# Altium schematic sheet Sheet3.SchDoc
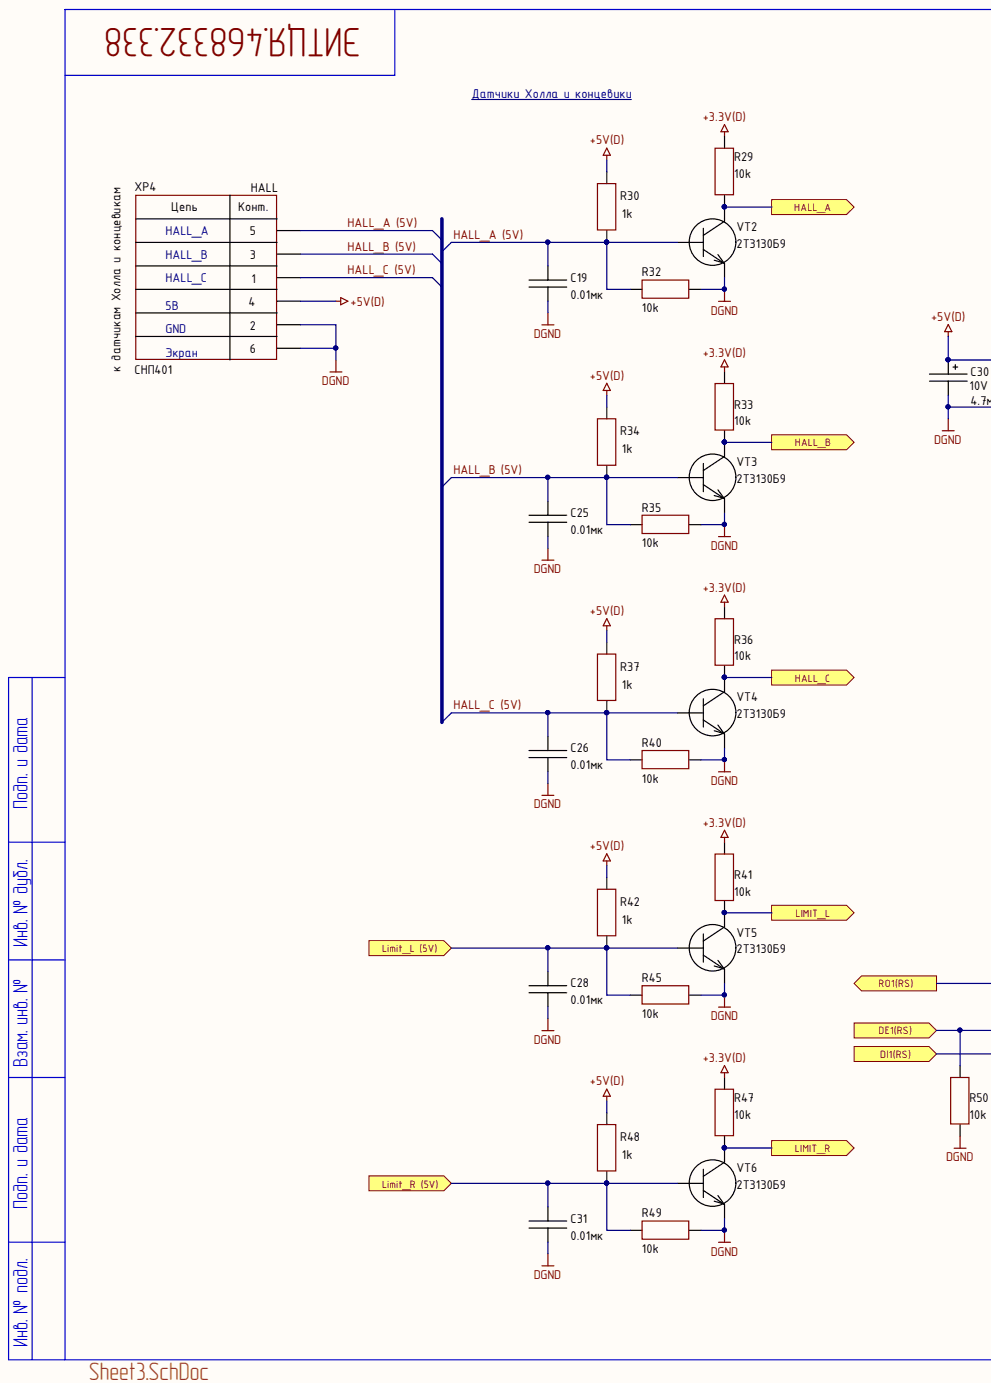
[diagram p0: Sheet3.SchDoc, left]
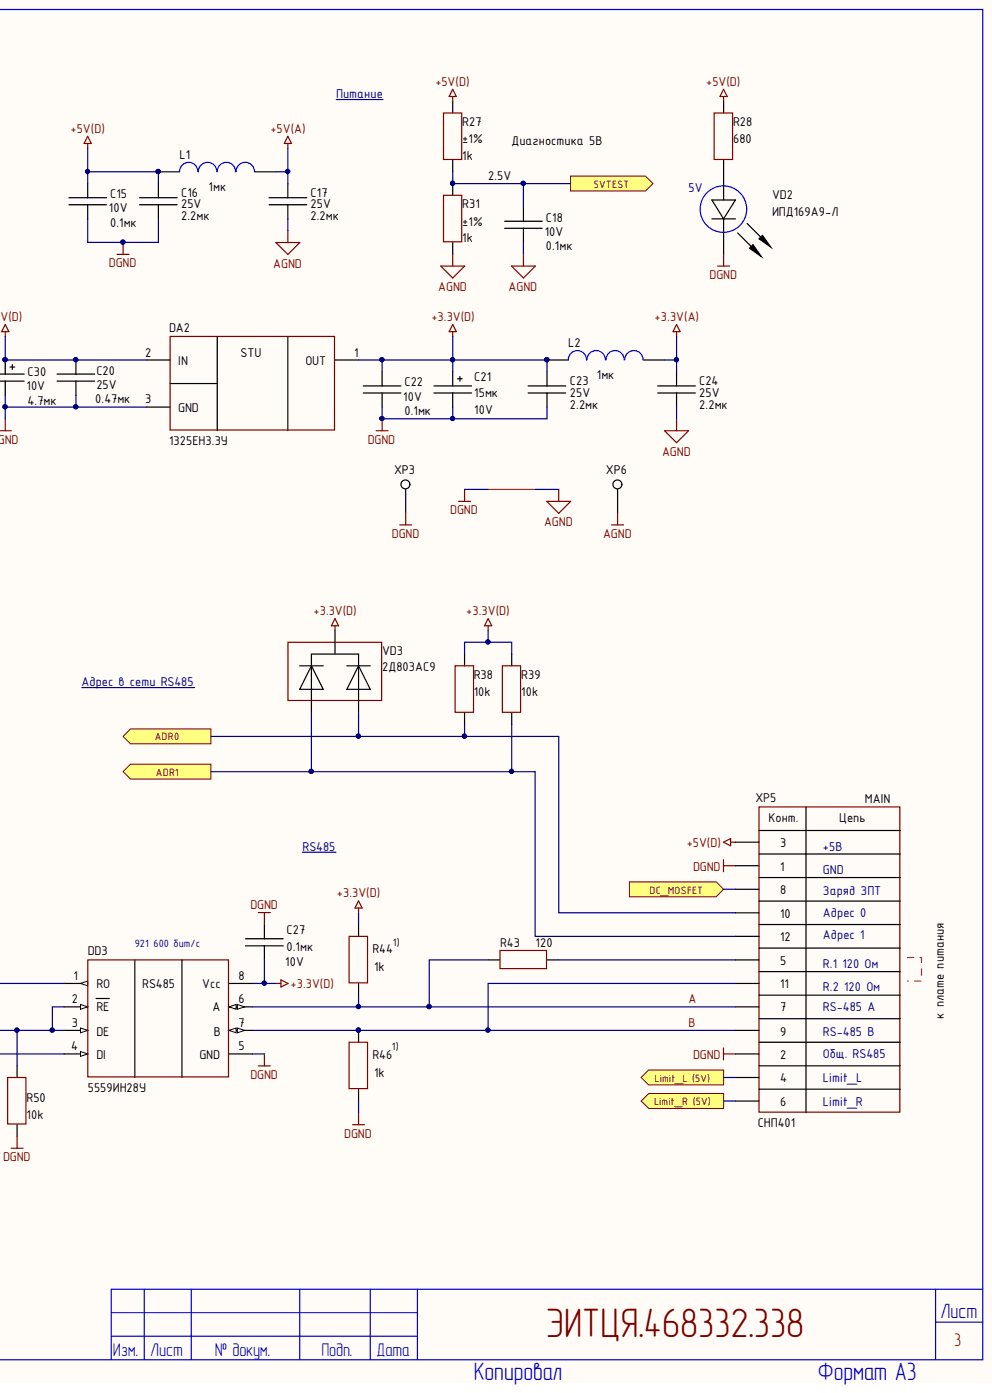
[diagram p1: Sheet3.SchDoc, right]

## Parts
C15  value 0.1мк  Voltage: 10V  [CAP: 0603P]  (hidden comment: Ceramic capacitor, 0.1мк, H90, +80-20%, 10V, 0603, -55...+125°C)
C16  value 2.2мк  Voltage: 25V  [CAP: 1210]  (hidden comment: Ceramic capacitor, 4.7мк, H90, +80 -20%, 25V, 1812 (4532М) (4.5x3.2), -55...+125°C)
C17  value 2.2мк  Voltage: 25V  [CAP: 1210]  (hidden comment: Ceramic capacitor, 4.7мк, H90, +80 -20%, 25V, 1812 (4532М) (4.5x3.2), -55...+125°C)
C18  value 0.1мк  Voltage: 10V  [CAP: 0603P]  (hidden comment: Ceramic capacitor, 0.1мк, H90, +80-20%, 10V, 0603, -55...+125°C)
C19  value 0.01мк  [CAP: 0603P]  (hidden comment: Ceramic capacitor, 0.01мк, H20, ±20%, 50V, 0603, -55...+125°C)
C20  value 0.47мк  Voltage: 25V  [CAP: 1210]  (hidden comment: Ceramic capacitor, 0.47мк, Н20, ±10%, 25V, 1210 (3225M),)
C21  value 15мк  Voltage: 10V  [CAP: TANTAL-B]  (hidden comment: Tantalum capacitor, 15мк, 10V, ±10%, , case B (3528), -55...+125°C)
C22  value 0.1мк  Voltage: 10V  [CAP: 0603P]  (hidden comment: Ceramic capacitor, 0.1мк, H90, +80-20%, 10V, 0603, -55...+125°C)
C23  value 2.2мк  Voltage: 25V  [CAP: 1210]  (hidden comment: Ceramic capacitor, 4.7мк, H90, +80 -20%, 25V, 1812 (4532М) (4.5x3.2), -55...+125°C)
C24  value 2.2мк  Voltage: 25V  [CAP: 1210]  (hidden comment: Ceramic capacitor, 4.7мк, H90, +80 -20%, 25V, 1812 (4532М) (4.5x3.2), -55...+125°C)
C25  value 0.01мк  [CAP: 0603P]  (hidden comment: Ceramic capacitor, 0.01мк, H20, ±20%, 50V, 0603, -55...+125°C)
C26  value 0.01мк  [CAP: 0603P]  (hidden comment: Ceramic capacitor, 0.01мк, H20, ±20%, 50V, 0603, -55...+125°C)
C27  value 0.1мк  Voltage: 10V  [CAP: 0603P]  (hidden comment: Ceramic capacitor, 0.1мк, H90, +80-20%, 10V, 0603, -55...+125°C)
C28  value 0.01мк  [CAP: 0603P]  (hidden comment: Ceramic capacitor, 0.01мк, H20, ±20%, 50V, 0603, -55...+125°C)
C30  value 4.7мк  Voltage: 10V  [CAP: TANTAL-A]  (hidden comment: Tantalum capacitor, 4.7мк, 10V, ±10%, 2Ohm, case A (3216), -55...+125°C)
C31  value 0.01мк  [CAP: 0603P]  (hidden comment: Ceramic capacitor, 0.01мк, H20, ±20%, 50V, 0603, -55...+125°C)
DA2  PartNumber: 1325ЕН3.3У  [KT-93-1]  (hidden comment: Linear voltage regulator, input 4.75...15V, 1.3V, output 3.3V, 0.8A, -40...+85°C, КТ-93-1)
DD3  5559ИН28У  [Н02.8-1В]
L1  value 1мк  [IND: 0603]  (hidden comment: Fixed inductor, 1мк, ±20%, 0603, 400mA, -55...+125°C)
L2  value 1мк  [IND: 0603]  (hidden comment: Fixed inductor, 1мк, ±20%, 0603, 400mA, -55...+125°C)
NT1  ValueManufacturerPartNumber  [NetTie_0603]  (value not drawn)
R27  value 1k  Tolerance: ±1%  [RES: 0603P]  (hidden comment: Resistor film, 1k, ±1%, 0603, 0.1W, -55...+125°C)
R28  value 680  [RES: 0603P]  (hidden comment: Resistor film, 680, ±5%, 0603, 0.1W, -55...+125°C)
R29  value 10k  [RES: 0603P]  (hidden comment: Resistor film, 10k, ±5%, 0603, 0.1W, -55...+125°C)
R30  value 1k  [RES: 0603P]  (hidden comment: Resistor film, 1k, ±1%, 0603, 0.1W, -55...+125°C)
R31  value 1k  Tolerance: ±1%  [RES: 0603P]  (hidden comment: Resistor film, 1k, ±1%, 0603, 0.1W, -55...+125°C)
R32  value 10k  [RES: 0603P]  (hidden comment: Resistor film, 10k, ±5%, 0603, 0.1W, -55...+125°C)
R33  value 10k  [RES: 0603P]  (hidden comment: Resistor film, 10k, ±5%, 0603, 0.1W, -55...+125°C)
R34  value 1k  [RES: 0603P]  (hidden comment: Resistor film, 1k, ±1%, 0603, 0.1W, -55...+125°C)
R35  value 10k  [RES: 0603P]  (hidden comment: Resistor film, 10k, ±5%, 0603, 0.1W, -55...+125°C)
R36  value 10k  [RES: 0603P]  (hidden comment: Resistor film, 10k, ±5%, 0603, 0.1W, -55...+125°C)
R37  value 1k  [RES: 0603P]  (hidden comment: Resistor film, 1k, ±1%, 0603, 0.1W, -55...+125°C)
R38  value 10k  [RES: 0603P]  (hidden comment: Resistor film, 10k, ±5%, 0603, 0.1W, -55...+125°C)
R39  value 10k  [RES: 0603P]  (hidden comment: Resistor film, 10k, ±5%, 0603, 0.1W, -55...+125°C)
R40  value 10k  [RES: 0603P]  (hidden comment: Resistor film, 10k, ±5%, 0603, 0.1W, -55...+125°C)
R41  value 10k  [RES: 0603P]  (hidden comment: Resistor film, 10k, ±5%, 0603, 0.1W, -55...+125°C)
R42  value 1k  [RES: 0603P]  (hidden comment: Resistor film, 1k, ±1%, 0603, 0.1W, -55...+125°C)
R43  value 120  [RES: 0805P]  (hidden comment: Resistor film, 120, ±5%, 0805, 0.125W, -55...+125°C)
R44  value 1k  [RES: 0603P]  (hidden comment: Resistor film, 1k, ±1%, 0603, 0.1W, -55...+125°C)
R45  value 10k  [RES: 0603P]  (hidden comment: Resistor film, 10k, ±5%, 0603, 0.1W, -55...+125°C)
R46  value 1k  [RES: 0603P]  (hidden comment: Resistor film, 1k, ±1%, 0603, 0.1W, -55...+125°C)
R47  value 10k  [RES: 0603P]  (hidden comment: Resistor film, 10k, ±5%, 0603, 0.1W, -55...+125°C)
R48  value 1k  [RES: 0603P]  (hidden comment: Resistor film, 1k, ±1%, 0603, 0.1W, -55...+125°C)
R49  value 10k  [RES: 0603P]  (hidden comment: Resistor film, 10k, ±5%, 0603, 0.1W, -55...+125°C)
R50  value 10k  [RES: 0603P]  (hidden comment: Resistor film, 10k, ±5%, 0603, 0.1W, -55...+125°C)
VD2  CommentText: 5V  PartNumber: ИПД169А9-Л  [LED: 0603G]  (hidden comment: LED, 20mA max, 2.1V typ, 1608, -55...+150°C)
VD3  PartNumber: 2Д803АС9  [SOT23]  (hidden comment: Diode array common anode, 50V, 200mA, 1.1V, , SOT-23,)
VT2  PartNumber: 2Т3130Б9  [SOT23]  (hidden comment: NPN transistor, 30V, 100mA, , 300mW, 0.7V, 500, SOT-23 (КТ-46), -55...+125°C)
VT3  PartNumber: 2Т3130Б9  [SOT23]  (hidden comment: NPN transistor, 30V, 100mA, , 300mW, 0.7V, 500, SOT-23 (КТ-46), -55...+125°C)
VT4  PartNumber: 2Т3130Б9  [SOT23]  (hidden comment: NPN transistor, 30V, 100mA, , 300mW, 0.7V, 500, SOT-23 (КТ-46), -55...+125°C)
VT5  PartNumber: 2Т3130Б9  [SOT23]  (hidden comment: NPN transistor, 30V, 100mA, , 300mW, 0.7V, 500, SOT-23 (КТ-46), -55...+125°C)
VT6  PartNumber: 2Т3130Б9  [SOT23]  (hidden comment: NPN transistor, 30V, 100mA, , 300mW, 0.7V, 500, SOT-23 (КТ-46), -55...+125°C)
XP3  DGND  [CON: PLS2-1]
XP4  CommentText: СНП401  [CON: M80-5000605]  (hidden comment: Вилка, 6pin, 2mm, 120VAC, 1.5A)
XP5  CommentText: СНП401  [CON: M80-5001205]  (hidden comment: Вилка, 12pin, 2mm, 100V, 1.5A)
XP6  AGND  [CON: PLS2-1]

## Ports
5VTEST, ADR0, ADR1, DC_MOSFET, DE1(RS), DI1(RS), HALL_A, HALL_B, HALL_C, LIMIT_L, Limit_L (5V), LIMIT_R, Limit_R (5V), RO1(RS)

## Nets
+3.3V(A): C24.2 L2.1
+3.3V(D): C21.1 C22.2 C23.2 C27.1 DA2.1 DD3.8(Vcc) L2.2 R29.1 R33.1 R36.1 R38.1 R39.1 R41.1 R44.1 R47.1 VD3.3
+5V(A): C17.2 L1.1
+5V(D): C15.2 C16.2 C20.2 C30.1 DA2.2 L1.2 R27.1 R28.1 R30.1 R34.1 R37.1 R42.1 R48.1 XP4.4 XP5.3
AGND: C17.1 C18.1 C24.1 NT1.2 R31.2 XP6.1
DGND: C15.1 C16.1 C19.2 C20.1 C21.2 C22.1 C23.1 C25.2 C26.2 C27.2 C28.2 C30.2 C31.2 DA2.3 DD3.5(GND) DD3.9 NT1.1 R32.1 R35.1 R40.1 R45.1 R46.2 R49.1 R50.2 VD2.2 VT2.2 VT3.2 VT4.2 VT5.2 VT6.2 XP3.1 XP4.2 XP4.6 XP5.1 XP5.2
5VTEST: C18.2 R27.2 R31.1 ; port 5VTEST
A: DD3.6(A) R43.2 R44.2 XP5.7
ADR0: R38.2 VD3.2 XP5.10 ; port ADR0
ADR1: R39.2 VD3.1 XP5.12 ; port ADR1
B: DD3.7(B) R46.1 XP5.9 XP5.11
DC_MOSFET: XP5.8 ; port DC_MOSFET
DE1(RS): DD3.2(RE) DD3.3(DE) R50.1 ; port DE1(RS)
DI1(RS): DD3.4(DI) ; port DI1(RS)
HALL_A: R29.2 VT2.3 ; port HALL_A
HALL_A (5V): C19.1 R30.2 R32.2 VT2.1 XP4.5
HALL_B: R33.2 VT3.3 ; port HALL_B
HALL_B (5V): C25.1 R34.2 R35.2 VT3.1 XP4.3
HALL_C: R36.2 VT4.3 ; port HALL_C
HALL_C (5V): C26.1 R37.2 R40.2 VT4.1 XP4.1
LIMIT_L: R41.2 VT5.3 ; port LIMIT_L
Limit_L (5V): C28.1 R42.2 R45.2 VT5.1 XP5.4 ; port Limit_L (5V)
LIMIT_R: R47.2 VT6.3 ; port LIMIT_R
Limit_R (5V): C31.1 R48.2 R49.2 VT6.1 XP5.6 ; port Limit_R (5V)
RO1(RS): DD3.1(RO) ; port RO1(RS)
NetR28_2: R28.2 VD2.1
NetR43_1: R43.1 XP5.5
N00110:

## Library data of the parts
C15 C18 C22 C27 = Ceramic_0603: Manufacturer: Гириконд; PartNumber: К10-79-10В-0,1 мкФ +80-20% H90; Component Kind: Standard; HelpURL: http://www.giricond.ru/files/k10-79_vp_2018_saiyt.pdf; Library Name: Capacitor.SchLib; Package: 0603; Temperature: -55...+125°C; Tolerance: +80-20%; TypeCapacitor: Ceramic capacitor; TypeDielectric: H90; ValueManufacturerPartNumber: Ceramic capacitor, 0.1мк, H90, +80-20%, 10V, 0603, -55...+125°C
C16 C17 C23 C24 = Ceramic_1210: Manufacturer: Монолит; PartNumber: К10-84в-3225М-25В-2,2 мкФ +80/-20%-Н90-N-A; Component Kind: Standard; HelpURL: https://www.digikey.com/product-detail/en/yageo/CC1210KKX7R9BB104/311-4522-1-ND/8025611; Library Name: Capacitor.SchLib; Package: 1210 (3225М); Temperature: -55...+125°C; Tolerance: +80 -20%; TypeCapacitor: Ceramic capacitor; TypeDielectric: H90; ValueManufacturerPartNumber: Ceramic capacitor, 4.7мк, H90, +80 -20%, 25V, 1812 (4532М) (4.5x3.2), -55...+125°C
C19 C25 C26 C28 C31 = Ceramic_0603: Manufacturer: Монолит; PartNumber: К10-84в-1608М-50В-0.01мк ±20%-Н20-N-A; Analog: MAX 00131676 (pin-to-pin); Component Kind: Standard; HelpURL: http://www.monolit.vitebsk.by/Files/K10-84.pdf; Library Name: Capacitor.SchLib; Package: 0603; Temperature: -55...+125°C; Tolerance: ±20%; TypeCapacitor: Ceramic capacitor; TypeDielectric: H20; ValueManufacturerPartNumber: Ceramic capacitor, 0.01мк, H20, ±20%, 50V, 0603, -55...+125°C; Voltage: 50V
C20 = Ceramic_0603: Manufacturer: Монолит; PartNumber: К10-84в-3225М-25В-0,47 мкФ ±10%-Н20-N-A; Analog: MAX 00150226; Component Kind: Standard; HelpURL: http://www.monolit.vitebsk.by/Files/K10-84.pdf; Library Name: Capacitor.SchLib; Package: 1210 (3225M); Tolerance: ±10%; TypeCapacitor: Ceramic capacitor; TypeDielectric: Н20; ValueManufacturerPartNumber: Ceramic capacitor, 0.47мк, Н20, ±10%, 25V, 1210 (3225M),
C21 = Tantal_B: Manufacturer: "Элеконд"; PartNumber: К53-68 В-10 В-15 мкФ ±10%; MAX: 00130628; Component Kind: Standard; Library Name: Capacitor.SchLib; Package: case B (3528); Temperature: -55...+125°C; Tolerance: ±10%; TypeCapacitor: Tantalum capacitor; ValueManufacturerPartNumber: Tantalum capacitor, 15мк, 10V, ±10%, , case B (3528), -55...+125°C
C30 = Tantal_A: Manufacturer: Элеконд; PartNumber: К53-68 "А"-10 В-4.7 мкф; MAX: 00130629; TypeDielectric: X7R; Component Kind: Standard; HelpURL: https://www.digikey.com/product-detail/en/avx-corporation/F930J107KAAAJ6/478-12404-1-ND/9645231; Impedance: 2Ohm; Library Name: Capacitor.SchLib; Package: case A (3216); Temperature: -55...+125°C; Tolerance: ±10%; TypeCapacitor: Tantalum capacitor; ValueManufacturerPartNumber: Tantalum capacitor, 4.7мк, 10V, ±10%, 2Ohm, case A (3216), -55...+125°C
DA2 = 1325ЕР1У: Linear voltage regulator 3V3; Manufacturer: Интеграл; MAX: 00141284; Component Kind: Standard; ComponentType: Linear voltage regulator; CurrentOutput: 0.8A; HelpURL: https://www.integral.by/sites/default/files/pdf/specifikaciya_serii_1325.pdf; Library Name: IC. Power supply.SchLib; MaxDropout: 1.3V; Package: КТ-93-1; Temperature: -40...+85°C; ValueManufacturerPartNumber: Linear voltage regulator, input 4.75...15V, 1.3V, output 3.3V, 0.8A, -40...+85°C, КТ-93-1; VoltageInput: input 4.75...15V; VoltageOutput: output 3.3V
DD3 = 5559ИН28У: RS485 USSR; Manufacturer: Миландр; DataRate: 30 Mbs; MAX: 00148111; Supply: 3-5V; Component Kind: Standard; HelpURL: https://ic.milandr.ru/products/interfeysnye_mikroskhemy/rs485-rs422/5559in28u/; Library Name: IC. Interface.SchLib; Package: Н02.8-1В; PartNumber: 5559ИН28У; Type: RS-485 transceiver
L1 L2 = Inductor_0603: Manufacturer: ЭРКОН; PartNumber: КИФ1608-1000 нГн ±20% О-А РКМУ.671340.002 ТУ; R: 0,8 Ом; Component Kind: Standard; HelpURL: https://www.erkon-nn.ru/catalog/14/kif-vp/; Library Name: Inductor.SchLib; MaxCurrent: 400mA; Package: 0603; Temperature: -55...+125°C; Tolerance: ±20%; TypeInductor: Fixed inductor; ValueManufacturerPartNumber: Fixed inductor, 1мк, ±20%, 0603, 400mA, -55...+125°C
NT1 = NetTie: Component Kind: Net Tie; Library Name: Connector.SchLib
R27 R30 R31 R34 R37 R42 R44 R46 R48 = Resistor_0603: Manufacturer: ОАО Ресурс; PartNumber: Р1-12-0,1ум.-1~кОм ±1%-М ШКАБ.434110.002 ТУ; MAX: 00133971; Component Kind: Standard; HelpURL: https://aoresurs.com/catalog/chip_rezistory/rezistory-r1-12-kategoriya-kachestva-vp-/; Library Name: Resistor.IntLib; Package: 0603; Power: 0.1W; Temperature: -55...+125°C; TypeResistor: Resistor film; ValueManufacturerPartNumber: Resistor film, 1k, ±1%, 0603, 0.1W, -55...+125°C
  R30 R34 R37 R42 R44 R46 R48: Tolerance: ±1%
R28 = Resistor_0603: Manufacturer: ОАО Ресурс; PartNumber: Р1-12-0,1ум.-680~кОм ±5%-М ШКАБ.434110.002 ТУ; MAX: 00134086; Component Kind: Standard; HelpURL: https://aoresurs.com/catalog/chip_rezistory/rezistory-r1-12-kategoriya-kachestva-vp-/; Library Name: Resistor.IntLib; Package: 0603; Power: 0.1W; Temperature: -55...+125°C; Tolerance: ±5%; TypeResistor: Resistor film; ValueManufacturerPartNumber: Resistor film, 680, ±5%, 0603, 0.1W, -55...+125°C
R29 R32 R33 R35 R36 R38-R41 R45 R47 R49 R50 = Resistor_0603: Manufacturer: ОАО Ресурс; PartNumber: Р1-12-0,1ум.-10~кОм ±5%-М ШКАБ.434110.002 ТУ; Analog: MAX 00133986 (1%); MAX: 00133985; Component Kind: Standard; HelpURL: https://aoresurs.com/catalog/chip_rezistory/rezistory-r1-12-kategoriya-kachestva-vp-/; Library Name: Resistor.IntLib; Package: 0603; Power: 0.1W; Temperature: -55...+125°C; Tolerance: ±5%; TypeResistor: Resistor film; ValueManufacturerPartNumber: Resistor film, 10k, ±5%, 0603, 0.1W, -55...+125°C
R43 = Resistor_0805: Manufacturer: Русские; PartNumber: Р1-12-0.125-120 Ом±5%-М; MAX: 00133848; Component Kind: Standard; Library Name: Resistor.SchLib; Package: 0805; Power: 0.125W; Temperature: -55...+125°C; Tolerance: ±5%; TypeResistor: Resistor film; ValueManufacturerPartNumber: Resistor film, 120, ±5%, 0805, 0.125W, -55...+125°C
VD2 = LED_single: Manufacturer: Протон; Analog: MAX 00129484 КР-1608 Kingbright (pin-to-pin); Color: GREEN; Component Kind: Standard; Current: 20mA max; Library Name: Diode.SchLib; Package: 1608; Temperature: -55...+150°C; TypeDiode: LED; ValueManufacturerPartNumber: LED, 20mA max, 2.1V typ, 1608, -55...+150°C; VoltageDrop: 2.1V typ
VD3 = Diode_array2: Manufacturer: Россия; MAX: 00122900; Component Kind: Standard; Current: 200mA; HelpURL: https://www.chipdip.ru/product/2d803as9; Library Name: Diode.SchLib; Package: SOT-23; TypeDiode: Diode array common anode; ValueManufacturerPartNumber: Diode array common anode, 50V, 200mA, 1.1V, , SOT-23,; Voltage: 50V; VoltageDrop: 1.1V
VT2-VT6 = BJT_NPN_132: Manufacturer: ООО НПК Далекс; CurrentGain: 500; MAX: 00123842; Component Kind: Standard; CurrentCE: 100mA; HelpURL: http://www.radioman-portal.ru/sprav/pp/transisters/sourse/2t3130.pdf; Library Name: Transistor.SchLib; MaxPower: 300mW; Package: SOT-23 (КТ-46); Temperature: -55...+125°C; TypeTransistor: NPN transistor; ValueManufacturerPartNumber: NPN transistor, 30V, 100mA, , 300mW, 0.7V, 500, SOT-23 (КТ-46), -55...+125°C; VoltageCE: 30V; VoltageSaturation: 0.7V
XP3 = PLS2-1: Test probe; Manufacturer: Электродеталь; PartNumber: СНП377-1ВП21-1; RefDes/Designator: MAX; Tolerance: СНП377; Шаг: 2mm; Analog: PLS2-1; Component Kind: Standard; HelpURL: https://www.elektrodetal.com/catalog/snp377/; Library Name: Connector.SchLib; Published: 2019; Type: Control pin; Publisher: TEN
XP4 = M80-5000605 Harwin: вилка на плату; Manufacturer: Электроделать; PartNumber: СНП401-6ВП21-3-1-1; Analog: MAX 00126397 (M80-5000605 pin-to-pin); Component Kind: Standard; CurrentMax: 1.5A; HelpURL: https://eu.mouser.com/ProductDetail/Harwin/M80-5000605?qs=yQtJ9TWM%2F1KaJIUUBEXorg%3D%3D; Library Name: Connector.SchLib; Multichannel: 6pin; Pitch: 2mm; TypeConnector: Вилка; ValueManufacturerPartNumber: Вилка, 6pin, 2mm, 120VAC, 1.5A; VoltageMax: 120VAC
XP5 = M80-5001205 Harwin: вилка на плату; Manufacturer: Электродеталь; PartNumber: СНП401-12ВП21-3-1-1; Analog: MAX 00138662 (M80-5101205 pin-to-pin); Component Kind: Standard; CurrentMax: 1.5A; HelpURL: https://eu.mouser.com/ProductDetail/Harwin/M80-5001205?qs=yQtJ9TWM%2F1IJJSuF%2FTVm0Q==; Library Name: Connector.SchLib; Multichannel: 12pin; Pitch: 2mm; TypeConnector: Вилка; ValueManufacturerPartNumber: Вилка, 12pin, 2mm, 100V, 1.5A; VoltageMax: 100V
XP6 = PLS2-1: Test probe; Manufacturer: Электродеталь; PartNumber: СНП377-1ВП21-1; MAX: 00130069; Tolerance: СНП377; Шаг: 2mm; Analog: PLS2-1; Component Kind: Standard; HelpURL: https://www.elektrodetal.com/catalog/snp377/; Library Name: Connector.SchLib; Published: 2019; Type: Control pin; Publisher: TEN
